# Altium schematic sheet MACHNGUON.SchDoc
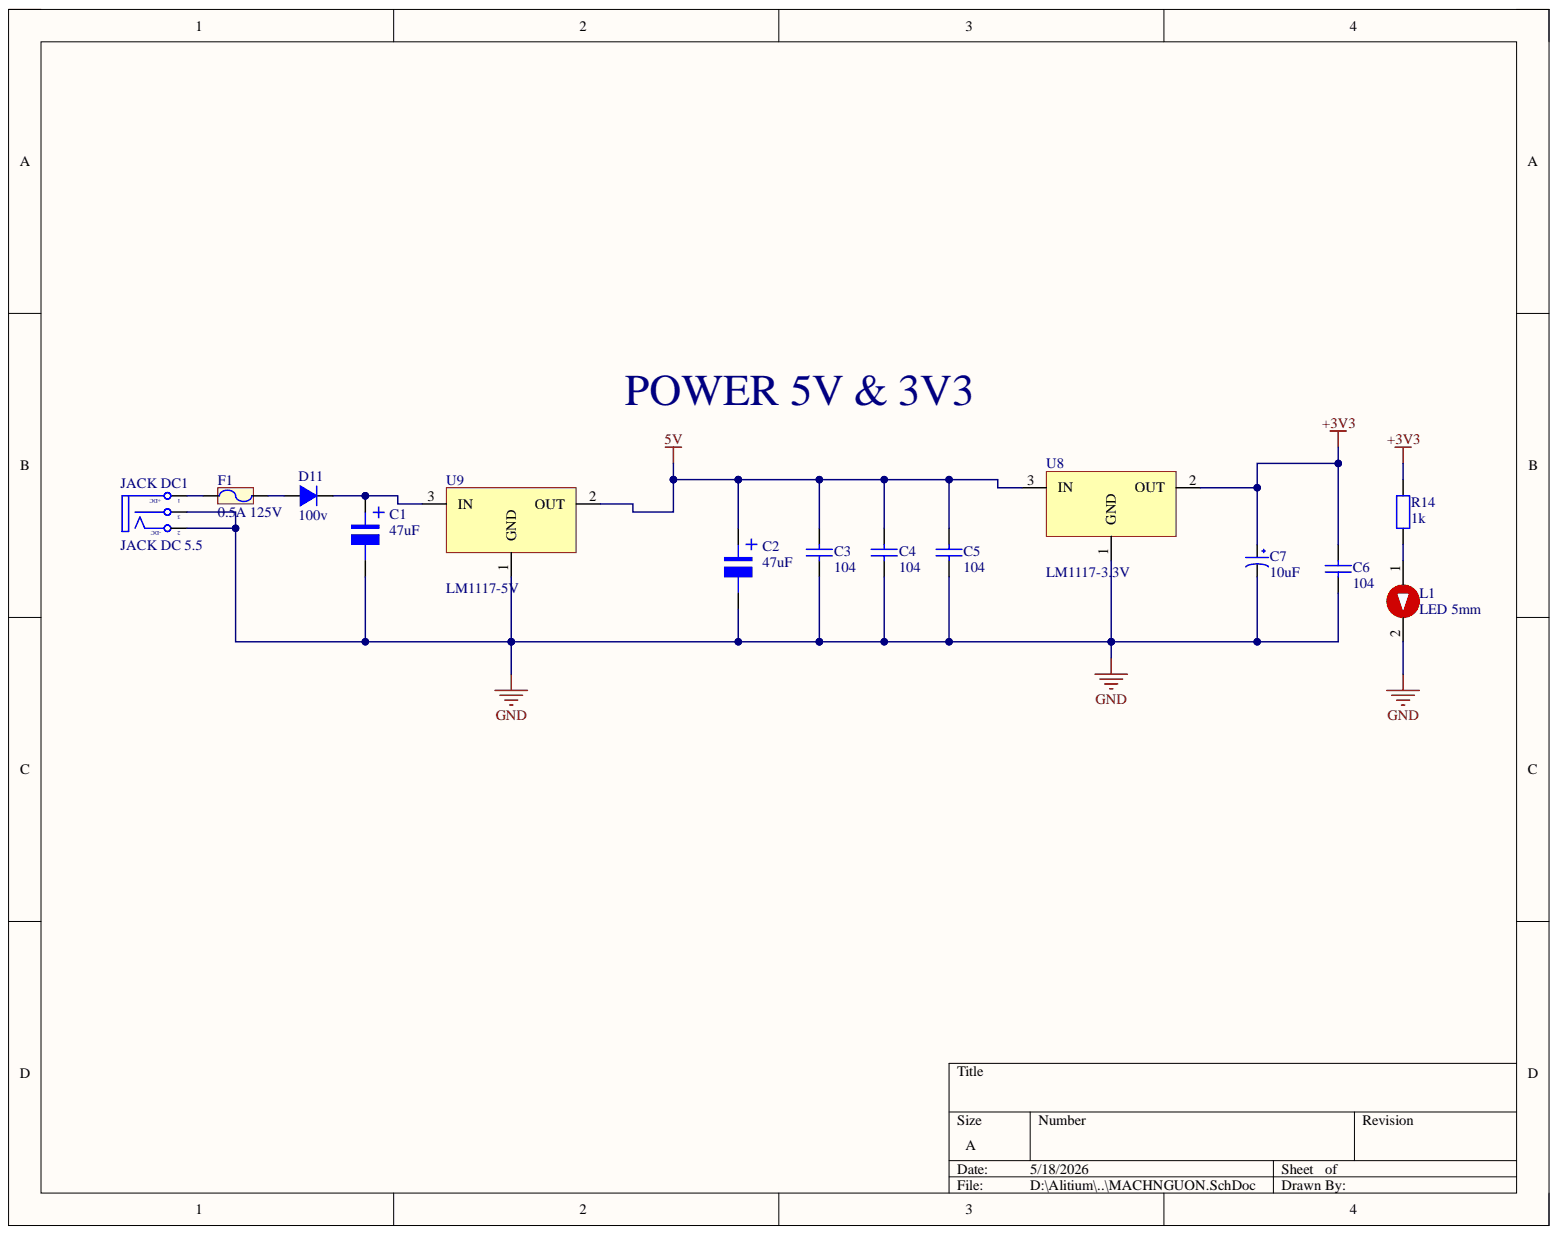
[diagram p0: MACHNGUON.SchDoc]

## Parts
C1  47uF  [tu nhom 6.3 (D)]
C2  47uF  [tu nhom 6.3 (D)]
C3  104  [tu gom]
C4  104  [tu gom]
C5  104  [tu gom]
C6  104  [tu gom]
C7  10uF  [tu hoa 13mm]
D11  100v  [DIODE 1A]
F1  0.5A 125V  [Cau Chi SMD1808]
JACK_DC1  JACK DC 5.5  [JACK DC 5.5]
L1  LED 5mm  [LED-5MM-RED]
R14  1k  [tro vach 1/2w]
U8  LM1117-3.3V  [TO220-123]
U9  LM1117-5V  [TO220-123]

## Nets
5V: C2.1 C3.1 C4.1 C5.1 U8.3 U9.2
+3V3: C6.1 C7.1 R14.2 U8.2
GND: C1.2 C2.2 C3.2 C4.2 C5.2 C6.2 C7.2 JACK_DC1.2 JACK_DC1.3 L1.2 U8.1 U9.1
NetC1_1: C1.1 D11.2 U9.3
NetD11_1: D11.1 F1.2
NetF1_1: F1.1 JACK_DC1.1
NetL1_1: L1.1 R14.1

## Library data of the parts
C1 C2 = Tu Nhom 47uF 16V: Dán; Don_Vi: Con; Ma_Hang: MH007468; So_Chan: 2; Ten_Linh_Kien: Tụ Nhôm 47uF 16V; Chung_Loai: Dán; Component Kind: Standard; Library Name: Altium_Lib_by_KhueNguyenCreator.IntLib
C3-C6 = Tu gom 104 50V: Cắm; Don_Vi: Con; Ma_Hang: MH004761; So_Chan: 2; Ten_Linh_Kien: Tụ gốm 104 100nF 50V; Chung_Loai: Cắm; Component Kind: Standard; Library Name: Altium_Lib_by_KhueNguyenCreator.IntLib
C7 = Tu Hoa 10uF 450V 13x20: Cắm; Don_Vi: Con; Ma_Hang: MH003950; So_Chan: 2; Ten_Linh_Kien: Tụ Hóa 10uF 450V 13x20MM; Chung_Loai: Cắm; Component Kind: Standard; Library Name: Altium_Lib_by_KhueNguyenCreator.IntLib
D11 = Diode 1N4007: Cắm; Don_Vi: Con; Ma_Hang: MH001063; Ten_Linh_Kien: Diode 1N4007 1A (DIP); Chung_Loai: Cắm; Component Kind: Standard; Library Name: Altium_Lib_by_KhueNguyenCreator.IntLib
F1 = Cau chi 0.5A 125V SMD1808: Ma_Hang: MH009241; Ten: Cầu Chì 0.5A 125V SMD1808 0451.500MRL; Component Kind: Standard; Description: Cau chi 0.5A 125V SMD1808; Library Name: Altium_Lib_by_KhueNguyenCreator.IntLib
JACK_DC1 = JACK DC 5.5: Value: Jac DC; Component Kind: Standard; Description: JACK DC 5.5; Library Name: Altium_Lib_by_KhueNguyenCreator.IntLib
L1 = LED 5mm Do: Cắm; Don_Vi: Con; Ma_Hang: MH008967; Ten_Linh_Kien: Led 5MM Phủ Đỏ (1000c); Chung_Loai: Cắm; Component Kind: Standard; Library Name: Altium_Lib_by_KhueNguyenCreator.IntLib
R14 = Tro Vach 1/2W 5% 1k: Cắm; Don_Vi: Con; Ma_Hang: MH007240; So_Chan: 2; Ten_Linh_Kien: Trở 5% 1/2w 1K; Chung_Loai: Cắm; Component Kind: Standard; Library Name: Altium_Lib_by_KhueNguyenCreator.IntLib
U8 U9 = LM1117: 800mA Low-Dropout Linear Regulator; Component Kind: Standard; Library Name: Altium Library.IntLib; Website: http://group3i.net; Creator: Nguyen Ba Bien
